# Altium schematic sheet esp32.SchDoc(esp32)
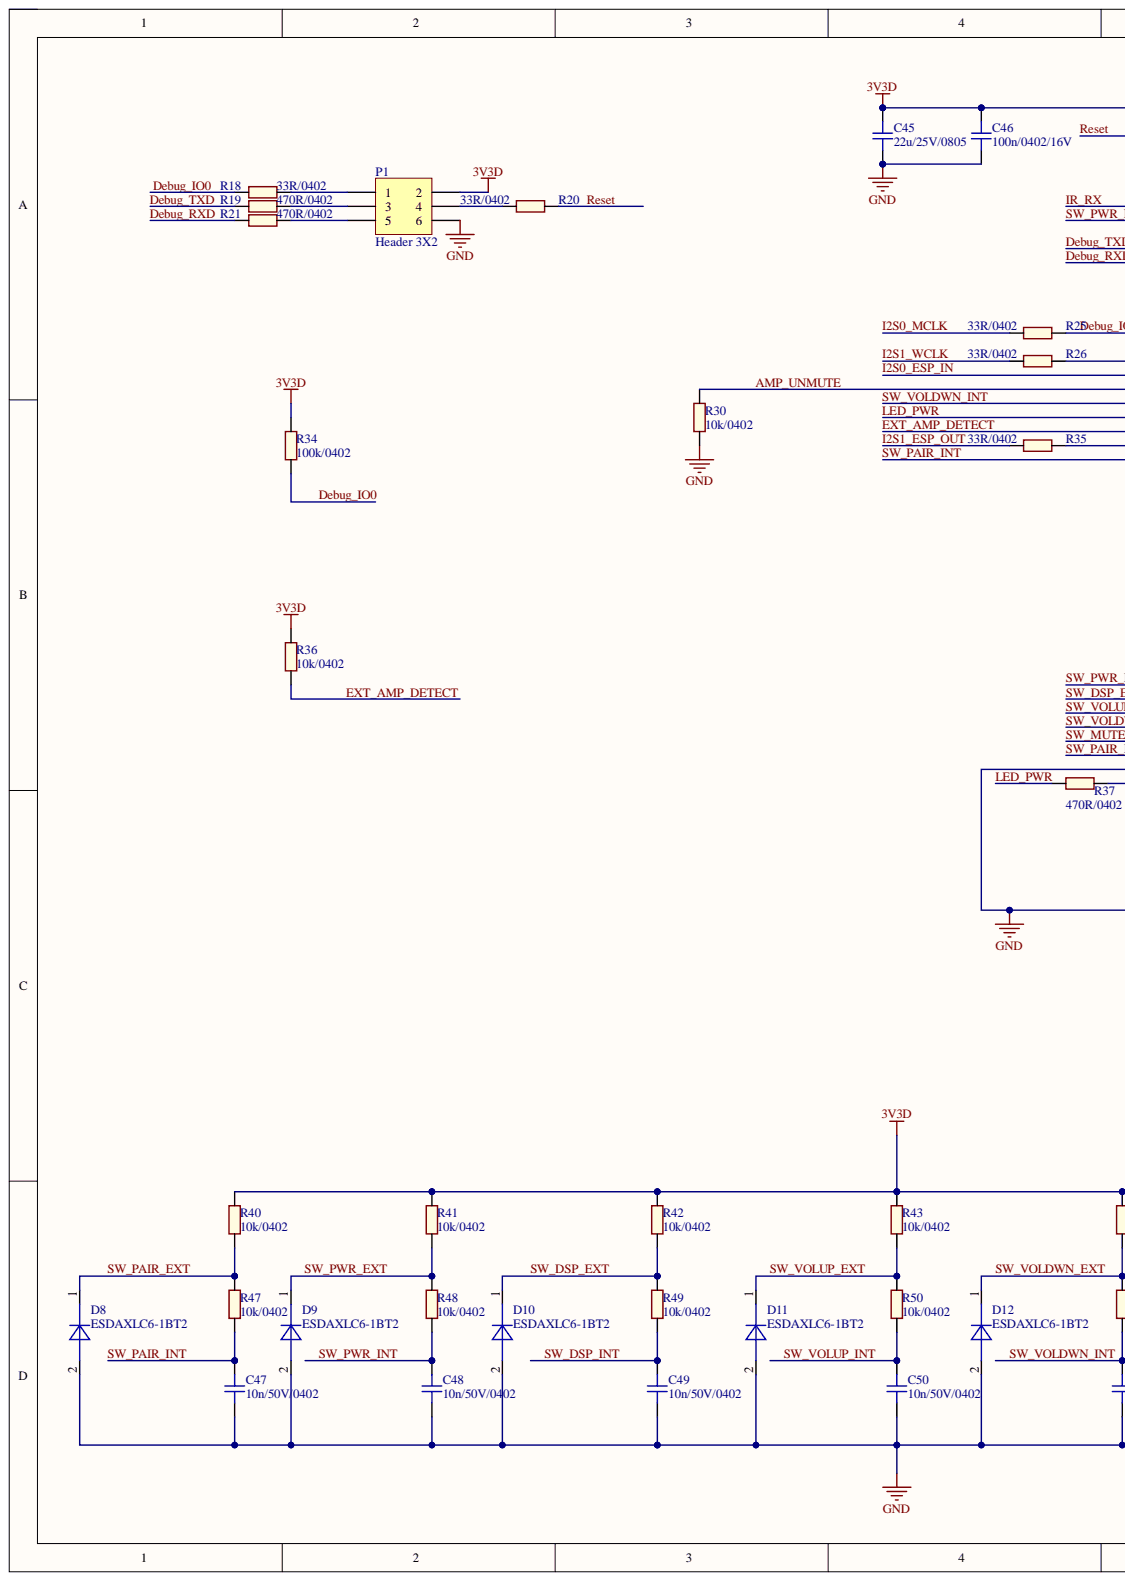
[diagram p0: esp32.SchDoc, left]
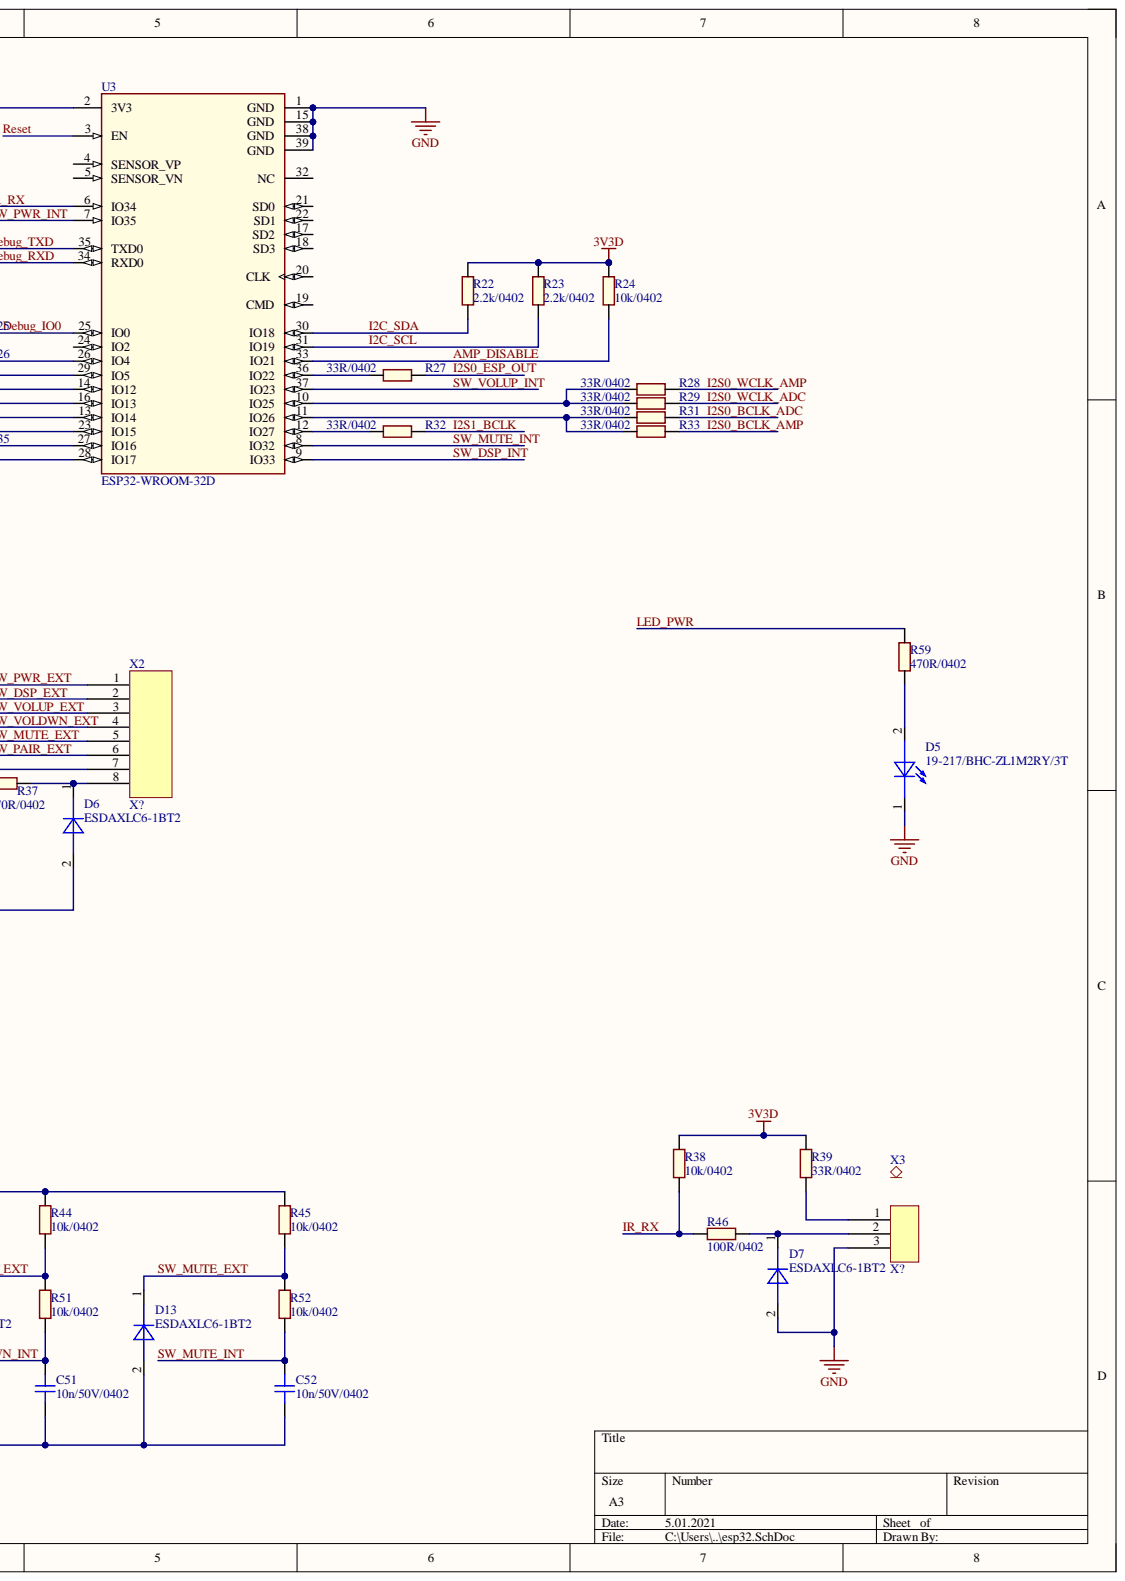
[diagram p1: esp32.SchDoc, right]

## Parts
C45  22u/25V/0805  [CAPC2013X140N]
C46  100n/0402/16V  [CAPC1005X55N-0]
C47  10n/50V/0402  [CAPC1005X55N-0]
C48  10n/50V/0402  [CAPC1005X55N-0]
C49  10n/50V/0402  [CAPC1005X55N-0]
C50  10n/50V/0402  [CAPC1005X55N-0]
C51  10n/50V/0402  [CAPC1005X55N-0]
C52  10n/50V/0402  [CAPC1005X55N-0]
D5  19-217/BHC-ZL1M2RY/3T  [LEDSC160X80X80-2N]
D6  ESDAXLC6-1BT2  [DFN98X58X50-2N]
D7  ESDAXLC6-1BT2  [DFN98X58X50-2N]
D8  ESDAXLC6-1BT2  [DFN98X58X50-2N]
D9  ESDAXLC6-1BT2  [DFN98X58X50-2N]
D10  ESDAXLC6-1BT2  [DFN98X58X50-2N]
D11  ESDAXLC6-1BT2  [DFN98X58X50-2N]
D12  ESDAXLC6-1BT2  [DFN98X58X50-2N]
D13  ESDAXLC6-1BT2  [DFN98X58X50-2N]
P1  Header 3X2  [HDR2X3]
R18  33R/0402  [RESC1005X40N]
R19  470R/0402  [RESC1005X40N]
R20  33R/0402  [RESC1005X40N]
R21  470R/0402  [RESC1005X40N]
R22  2.2k/0402  [RESC1005X40N]
R23  2.2k/0402  [RESC1005X40N]
R24  10k/0402  [RESC1005X40N]
R25  33R/0402  [RESC1005X40N]
R26  33R/0402  [RESC1005X40N]
R27  33R/0402  [RESC1005X40N]
R28  33R/0402  [RESC1005X40N]
R29  33R/0402  [RESC1005X40N]
R30  10k/0402  [RESC1005X40N]
R31  33R/0402  [RESC1005X40N]
R32  33R/0402  [RESC1005X40N]
R33  33R/0402  [RESC1005X40N]
R34  100k/0402  [RESC1005X40N]
R35  33R/0402  [RESC1005X40N]
R36  10k/0402  [RESC1005X40N]
R37  470R/0402  [RESC1005X40N]
R38  10k/0402  [RESC1005X40N]
R39  33R/0402  [RESC1005X40N]
R40  10k/0402  [RESC1005X40N]
R41  10k/0402  [RESC1005X40N]
R42  10k/0402  [RESC1005X40N]
R43  10k/0402  [RESC1005X40N]
R44  10k/0402  [RESC1005X40N]
R45  10k/0402  [RESC1005X40N]
R46  100R/0402  [RESC1005X40N]
R47  10k/0402  [RESC1005X40N]
R48  10k/0402  [RESC1005X40N]
R49  10k/0402  [RESC1005X40N]
R50  10k/0402  [RESC1005X40N]
R51  10k/0402  [RESC1005X40N]
R52  10k/0402  [RESC1005X40N]
R59  470R/0402  [RESC1005X40N]
U3  ESP32-WROOM-32D  [ESP32-WROOM-32]
X2  X?  [JST PH 2MM 8 PIN]
X3  X?  [JST PH 2MM 3 PIN]

## Nets
3V3D: C45.2 C46.2 P1.2 R22.1 R23.1 R24.2 R34.2 R36.2 R38.2 R39.2 R40.1 R41.1 R42.1 R43.1 R44.1 R45.1 U3.2(3V3)
GND: C45.1 C46.1 C47.2 C48.2 C49.2 C50.2 C51.2 C52.2 D5.1 D6.2 D7.2 D8.2 D9.2 D10.2 D11.2 D12.2 D13.2 P1.6 R30.1 U3.1(GND) U3.15(GND) U3.38(GND) U3.39(GND) X2.7 X3.3
AMP_DISABLE: R24.1 U3.33(IO21)
AMP_UNMUTE: R30.2 U3.14(IO12)
Debug_IO0: R18.1 R25.2 R34.1 U3.25(IO0)
Debug_RXD: R21.2 U3.34(RXD0)
Debug_TXD: R19.2 U3.35(TXD0)
EXT_AMP_DETECT: R36.1 U3.23(IO15)
I2C_SCL: R23.2 U3.31(IO19)
I2C_SDA: R22.2 U3.30(IO18)
I2S0_BCLK_ADC: R31.2
I2S0_BCLK_AMP: R33.2
I2S0_ESP_IN: U3.29(IO5)
I2S0_ESP_OUT: R27.2
I2S0_MCLK: R25.1
I2S0_WCLK_ADC: R29.2
I2S0_WCLK_AMP: R28.2
I2S1_BCLK: R32.2
I2S1_ESP_OUT: R35.1
I2S1_WCLK: R26.1
IR_RX: R38.1 R46.2 U3.6(IO34)
LED_PWR: R37.1 R59.2 U3.13(IO14)
Reset: R20.2 U3.3(EN)
SW_DSP_EXT: D10.1 R42.2 R49.1 X2.2
SW_DSP_INT: C49.1 R49.2 U3.9(IO33)
SW_MUTE_EXT: D13.1 R45.2 R52.1 X2.5
SW_MUTE_INT: C52.1 R52.2 U3.8(IO32)
SW_PAIR_EXT: D8.1 R40.2 R47.1 X2.6
SW_PAIR_INT: C47.1 R47.2 U3.28(IO17)
SW_PWR_EXT: D9.1 R41.2 R48.1 X2.1
SW_PWR_INT: C48.1 R48.2 U3.7(IO35)
SW_VOLDWN_EXT: D12.1 R44.2 R51.1 X2.4
SW_VOLDWN_INT: C51.1 R51.2 U3.16(IO13)
SW_VOLUP_EXT: D11.1 R43.2 R50.1 X2.3
SW_VOLUP_INT: C50.1 R50.2 U3.37(IO23)
NetD5_2: D5.2 R59.1
NetD6_1: D6.1 R37.2 X2.8
NetD7_1: D7.1 R46.1 X3.2
NetP1_1: P1.1 R18.2
NetP1_3: P1.3 R19.1
NetP1_4: P1.4 R20.1
NetP1_5: P1.5 R21.1
NetR26_2: R26.2 U3.26(IO4)
NetR27_1: R27.1 U3.36(IO22)
NetR28_1: R28.1 R29.1 U3.10(IO25)
NetR31_1: R31.1 R33.1 U3.11(IO26)
NetR32_1: R32.1 U3.12(IO27)
NetR35_2: R35.2 U3.27(IO16)
NetR39_1: R39.1 X3.1

Not connected: U3 4(SENSOR_VP) 5(SENSOR_VN) 17(SD2) 18(SD3) 19(CMD) 20(CLK) 21(SD0) 22(SD1) 24(IO2) 32(NC)

## Library data of the parts
C45 = 304206: CAP / CERA / 330nF / 25V / 5% / X8R (EIA) / -55°C to 150°C / 0805(2012) / SMD / -; Manufacturer: MuRata; Manufacturer Order Number: GCM21BR91E334JA37; Multiple Parts: No; Alternate View: Yes; Automotive Qualified: AEC Q200; Combined Parameters: TC:X8R (EIA);Tol.:-5%/+5%;Vdc:25V; ComponentStatus: Released; Database Table Name: TPartsAll; Legacy DeviceID: CAP01009910; Package Imperial: 0805; Package Metric: 2012; PLMStatus: Active; QuickPick: 330nF / 25V / 5% / X8R (EIA) / CAPC2013X140N; Style: Single; Symbol Type: Capacitor; Single; Standard; 2 Pins; Type: Standard; Version Number: V01; Application: Standard; Article Number: 304206; Component Kind: Standard; ComponentLink1Description: Vendor WebSite; ComponentLink1URL: http://www.murata.com/; ComponentLink2Description: Datasheet; ComponentLink2URL: http://webnetprod.muc.infineon.com/PCBPortal_Prod/WorkFlows/AltiumComponent/StandardDocumentation?Versionid=304207; Legacy PartNumber: CAP01009910; Library Name: PCBLibraryData.SVNDbLib; Package Description: Capacitor,non-polarized,Chip;2.00mm L X 1.25mm W X 1.40mm H; PackageReference: CAPC2013X140N; Part Type: Capacitor; ROHS Compliant: Y; Second Source allowed: Yes; Supplier 1: Farnell; Supplier 2: DigiKey; Supplier 3: Mouser; Technology: Ceramic; Temperature max: 150; Temperature min: -55; Temperature Range: Military; Tolerance Neg: 5%; Tolerance Pos: 5%; Value: 330nF; Voltage DC (max): 25V
C46-C52 = 263539: CAP / CERA / 10nF / 6.3V / 10% / X5R (EIA) / -55°C to 85°C / 0402(1005) / SMD / -; Manufacturer: MuRata; Manufacturer Order Number: GRM155R60J103KA01; Alternate View: Yes; Automotive Qualified: No; Combined Parameters: TC:X5R (EIA);Tol.:-10%/+10%;Vdc:6.3V; ComponentStatus: Released; Database Table Name: TPartsAll; Legacy DeviceID: CAP01001500; Package Imperial: 0402; Package Metric: 1005; PLMStatus: Not Recommended For New Designs; QuickPick: 10nF / 6.3V / 10% / X5R (EIA) / CAPC1005X55N-0; Style: Single; Symbol Type: Capacitor; Single; Standard; 2 Pins; Type: Standard; Version Number: V01; Application: Standard; Article Number: 263539; Component Kind: Standard; ComponentLink1Description: Vendor WebSite; ComponentLink1URL: http://www.murata.com/; ComponentLink2Description: Datasheet; ComponentLink2URL: http://webnetprod.muc.infineon.com/PCBPortal_Prod/WorkFlows/AltiumComponent/StandardDocumentation?Versionid=263540; Legacy PartNumber: CAP01001500; Library Name: PCBLibraryData.SVNDbLib; Multiple Parts: No; Package Description: Capacitor,non-polarized,Chip;1.00mm L X 0.50mm W X 0.55mm H; PackageReference: CAPC1005X55N-0; Part Type: Capacitor; ROHS Compliant: Y; Second Source allowed: Yes; Supplier 1: Farnell; Supplier 2: DigiKey; Supplier 3: Mouser; Technology: Ceramic; Temperature max: 85; Temperature min: -55; Temperature Range: Military; Tolerance Neg: 10%; Tolerance Pos: 10%; Value: 10nF; Voltage DC (max): 6.3V
D5 = 393589: Surface Mount LED, Green, 570nm; Manufacturer: OSRAM Opto Semiconductors; Manufacturer Order Number: LG Q971-KN-1; Automotive Qualified: No; Combined Parameters: Vf:2.2V;If:20mA;Ur:12V;Ir:20nA;Pmax:-; ComponentStatus: Released; Database Table Name: TPartsAll; Legacy DeviceID: DIO01300117; PLMStatus: Obsolete; QuickPick: LED / 0603; Second Source allowed: Yes; Style: Single; Symbol Type: Standard LED Symbol, 1 Cathode, 2 Anode; Version Number: V01; Voltage DC (max): na; Application: Standard; Article Number: 393589; Component Kind: Standard; ComponentLink1Description: Vendor WebSite; ComponentLink1URL: http://www.osram-os.com; ComponentLink2Description: Datasheet; ComponentLink2URL: http://webnetprod.muc.infineon.com/PCBPortal_Prod/WorkFlows/AltiumComponent/StandardDocumentation?Versionid=393590; Legacy PartNumber: DIO01300117; Library Name: PCBLibraryData.SVNDbLib; Package Description: LED, Side Concave, 2 pin, 1.60mm W X 0.80mm L X 0.80mm H; PackageReference: 0603; Part Type: Diode; ROHS Compliant: Y; Supplier 1: Farnell; Supplier 2: DigiKey; Supplier 3: Mouser; Temperature max: 85; Temperature min: -30; Temperature Range: Military; Type: LED; Value: Green
D6-D13 = 612400: High-voltage switching diode, Vr 200V; Manufacturer: Nexperia; Manufacturer Order Number: BAS21LLYL; Automotive Qualified: AEC Q101; Combined Parameters: Vf:1.25V;If:330mA;Ur:200V;Ir:100nA;Pmax:610mW; ComponentStatus: Released; Database Table Name: TPartsAll; PLMStatus: Active; QuickPick: Standard Diode / SOD882; Second Source allowed: Yes; Style: Single; Symbol Type: Standard Diode Symbol, 2 Leads, 1 Cathode, 2 Anode; Type: Standard Diode; Value: BAS21LLYL; Version Number: V01; Voltage DC (max): na; Application: Switching; Article Number: 612400; Component Kind: Standard; ComponentLink1Description: Vendor WebSite; ComponentLink1URL: http://www.nexperia.com; ComponentLink2Description: Datasheet; ComponentLink2URL: http://webnetprod.muc.infineon.com/PCBPortal_Prod/WorkFlows/AltiumComponent/StandardDocumentation?Versionid=612401; Library Name: PCBLibraryData.SVNDbLib; Package Description: Diode, SMD, 2 pin, 0.985 mm L X 0.585 mm W X 0.50 mm H body; PackageReference: SOD882; Part Type: Diode; ROHS Compliant: Y; Supplier 1: Farnell; Supplier 2: DigiKey; Supplier 3: Mouser; Supplier Part Number 1: 3020932; Supplier Part Number 2: 1727-7664-1-ND; Supplier Part Number 3: 771-BAS21LLYL; Temperature max: 150; Temperature min: -55; Temperature Range: Military
P1 = Header 3X2: Header, 3-Pin, Dual row; Component Kind: Standard; LatestRevisionDate: 17-Jul-2002; LatestRevisionNote: Re-released for DXP Platform.; Library Name: Miscellaneous Connectors.IntLib; Signal Integrity: Connector; Publisher: Altium Limited
R18-R52 R59 = 342167: RES / STD / 10k / 63mW / 1% / 100ppm/K / -55°C to 155°C / 0402 / SMD / -; Manufacturer: Vishay; Manufacturer Order Number: CRCW040210K0FK; Multiple Parts: No; Alternate View: Yes; Automotive Qualified: AEC Q200; Combined Parameters: TCR:100ppm/K;Tol.:-1%/+1%;Vdc:50V;Idc:-;P:63mW; ComponentStatus: Released; Database Table Name: TPartsAll; Legacy DeviceID: RES01800457; PLMStatus: Active; QuickPick: 10k / 1% / 0.063 / 0402; Style: Single; Symbol Type: Resistor; Single; Standard; 2 Pins; Version Number: V01; Voltage DC (max): 50V; Article Number: 342167; Component Kind: Standard; ComponentLink1Description: Vendor WebSite; ComponentLink1URL: http://www.vishay.com; ComponentLink2Description: Datasheet; ComponentLink2URL: http://webnetprod.muc.infineon.com/PCBPortal_Prod/WorkFlows/AltiumComponent/StandardDocumentation?Versionid=342168; Legacy PartNumber: RES01800457; Library Name: PCBLibraryData.SVNDbLib; PackageReference: 0402; Part Type: Resistor; ROHS Compliant: Y; Second Source allowed: Yes; Supplier 1: Farnell; Supplier 2: DigiKey; Supplier 3: Mouser; Technology: Thick Film; Temperature max: 155; Temperature min: -55; Temperature Range: Military; Tolerance Neg: 1%; Tolerance Pos: 1%; Type: Standard; Value: 10k
U3 = 593702: Powerful, generic Wi-Fi+BT+BLE MCU module; Manufacturer: Espressif Systems; Alternate View: No; Combined Parameters: Communication -;Vdc min/max:3V/3.6V;Iout:1.1mA;Fmax:2.5GHz; ComponentStatus: Released; Database Table Name: TPartsAll; Legacy DeviceID: ESP_ESP32-WROOM-32; PLMStatus: Active; QuickPick: SMD; Second Source allowed: Yes; Version Number: V01; Voltage DC (max): na; Application: Communication; Article Number: 593702; Automotive Qualified: No; Component Kind: Standard; ComponentLink1Description: Vendor WebSite; ComponentLink1URL: http://bbs.espressif.com/; ComponentLink2Description: Datasheet; ComponentLink2URL: http://webnetprod.muc.infineon.com/PCBPortal_Prod/WorkFlows/AltiumComponent/StandardDocumentation?Versionid=593703; Library Name: PCBLibraryData.SVNDbLib; Manufacturer Order Number: ESP32-WROOM-32; Multiple Parts: No; Package Description: Module, SMD; 1.27 mm Pitch, 39 pin, 18.00 mm L X 25.50 mm W X 3.20 mm H body; PackageReference: SMD; Part Type: Integrated Circuit; ROHS Compliant: Y; Supplier 1: Farnell; Supplier 2: DigiKey; Supplier 3: Mouser; Supplier Part Number 3: 356-ESP-WROOM-32; Symbol Type: Powerful, generic Wi-Fi+BT+BLE MCU module; Temperature max: 85; Temperature min: -40; Temperature Range: Industrial; Value: ESP32-WROOM-32
X2 = JST PH 2mm 8 pin: Component Kind: Standard; Library Name: MarkusLib.IntLib
X3 = JST PH 2mm 3pin: Component Kind: Standard; Library Name: MarkusLib.IntLib
